# Altium schematic sheet KlusterLab HMI.SchDoc("KlusterLab HMI")
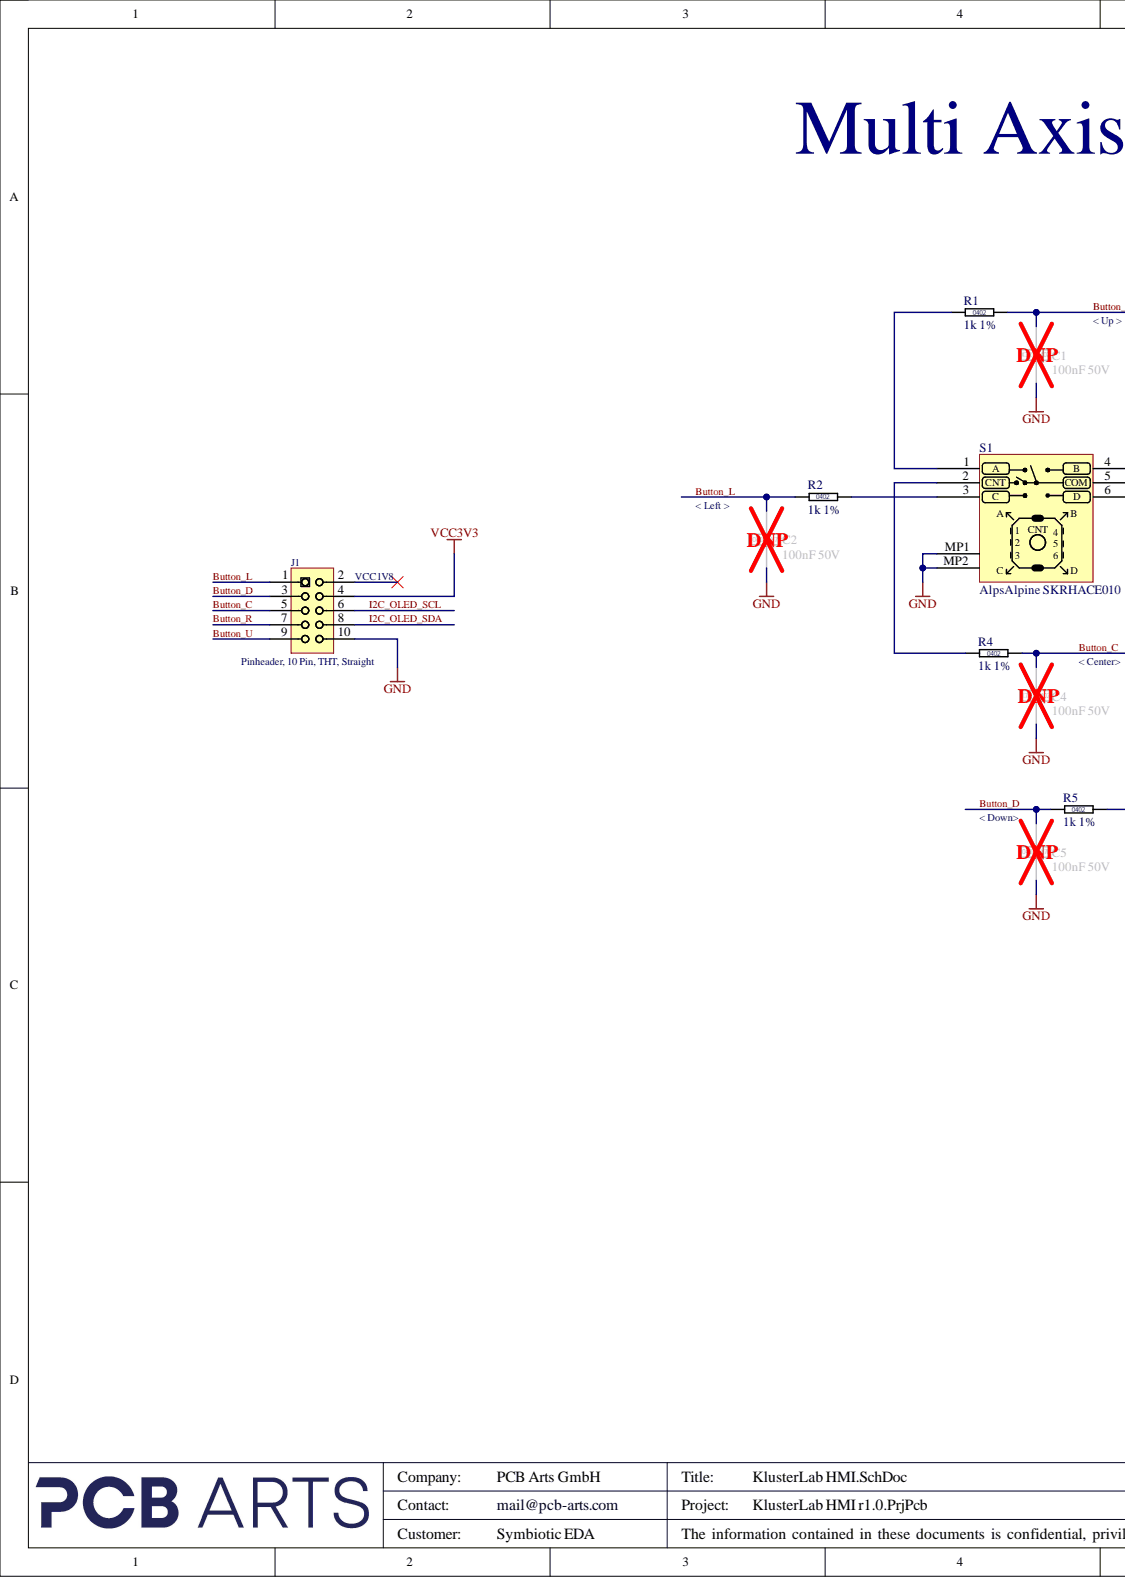
[diagram p0: KlusterLab HMI.SchDoc, left]
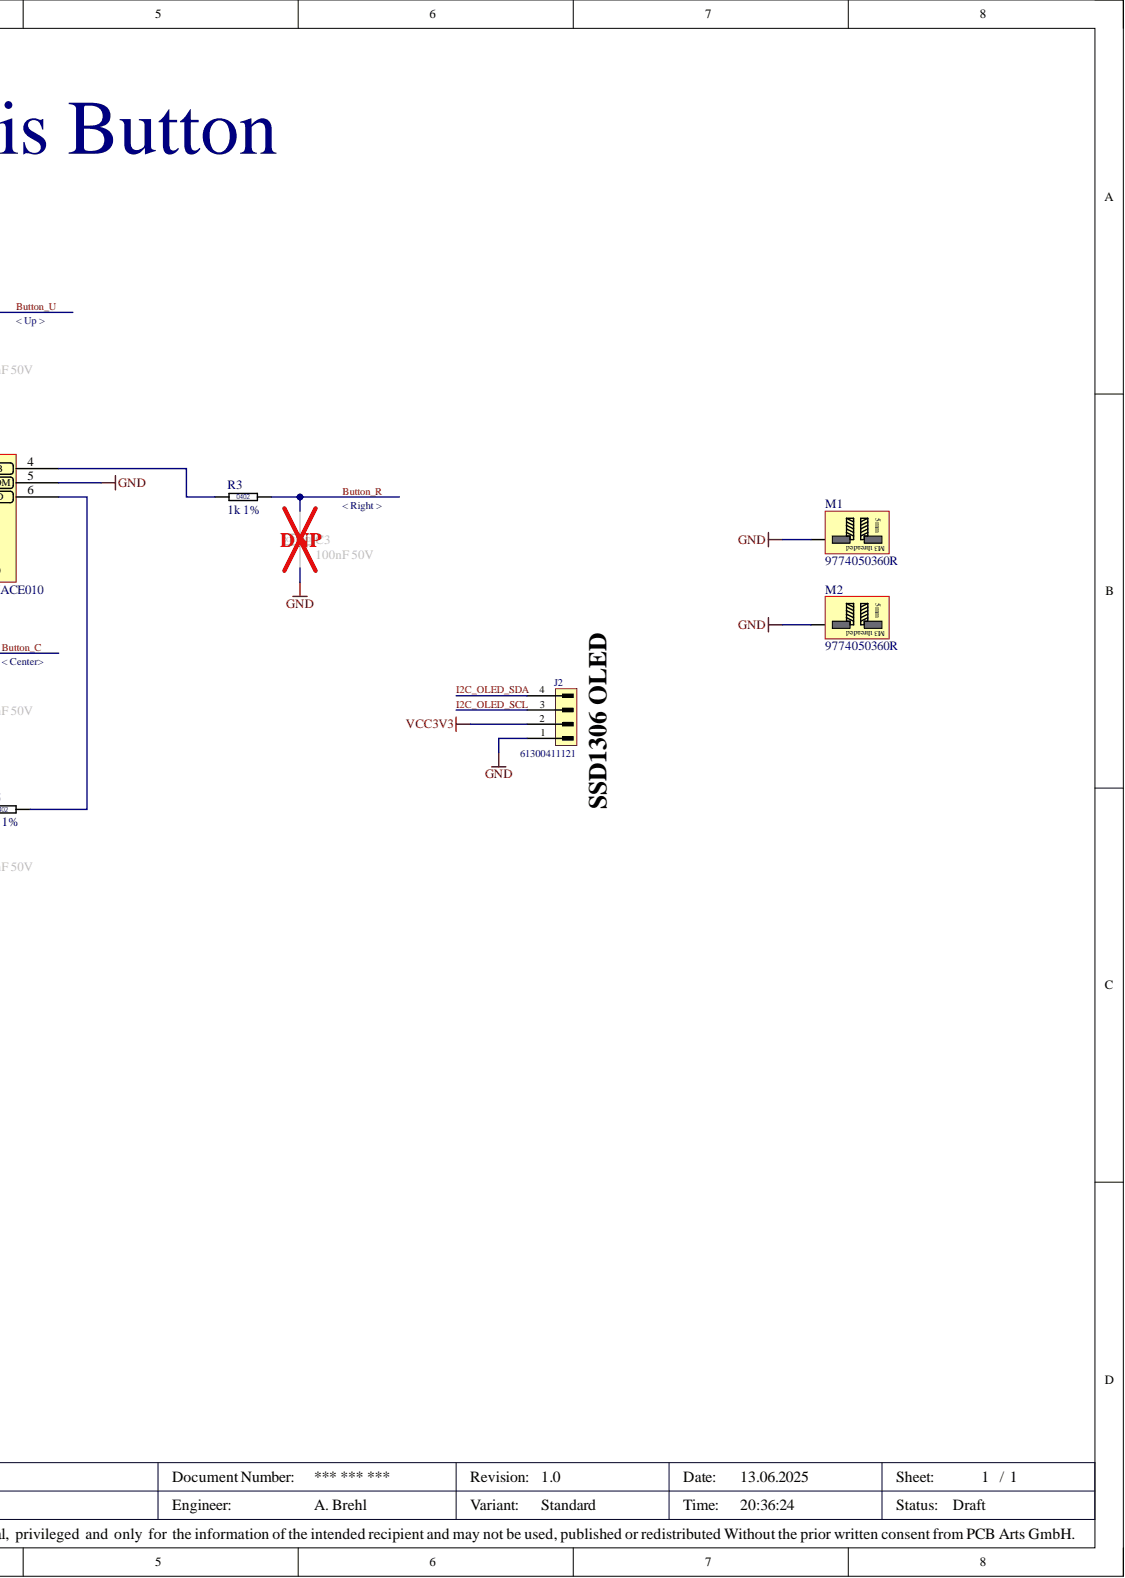
[diagram p1: KlusterLab HMI.SchDoc, right]

## Parts
C1  100nF 50V  [CAP_0402_L]  (no BOM)
C2  100nF 50V  [CAP_0402_L]  (no BOM)
C3  100nF 50V  [CAP_0402_L]  (no BOM)
C4  100nF 50V  [CAP_0402_L]  (no BOM)
C5  100nF 50V  [CAP_0402_L]  (no BOM)
J1  Pinheader, 10 Pin, THT, Straight  [62701021621]
J2  value 61300411121  Order Code #1: 61300411121  [WE_61300411121]  (hidden comment: WE / WR-PHD / 61300411121 / PinHeader / 1x4 Pin / RM2.54 / Top Entry / Male)
M1  9774050360R  [9774050360R]
M2  9774050360R  [9774050360R]
R1  1k 1%  [RES_0402_L]
R2  1k 1%  [RES_0402_L]
R3  1k 1%  [RES_0402_L]
R4  1k 1%  [RES_0402_L]
R5  1k 1%  [RES_0402_L]
S1  AlpsAlpine SKRHACE010  Manufacturer 1 Prt. Nr.: SKRHACE010  [AlpsAlpine_SKRHACE010]

## Nets
GND: C1.2 C2.2 C3.2 C4.2 C5.2 J1.10 J2.1 M1.1 M2.1 S1.5(COM) S1.MP1 S1.MP2(C)
VCC3V3: J1.4 J2.2
Button_C: C4.1 J1.5 R4.1
Button_D: C5.1 J1.3 R5.1
Button_L: C2.1 J1.1 R2.1
Button_R: C3.1 J1.7 R3.1
Button_U: C1.1 J1.9 R1.1
I2C_OLED_SCL: J1.6 J2.3
I2C_OLED_SDA: J1.8 J2.4
NetR1_2: R1.2 S1.1(A)
NetR2_2: R2.2 S1.3(C)
NetR3_2: R3.2 S1.4(B)
NetR4_2: R4.2 S1.2(CNT)
NetR5_2: R5.2 S1.6(D)

Not connected: J1 2

## Library data of the parts
C1-C5 = CAP_0402_100nF_50V: Multilayer Ceramic Capacitors MLCC - SMD/SMT 0402 50VDC; Manufacturer 1: Murata Electronics; Manufacturer 1 Prt. Nr.: GCM155L8EH104KE07D; Manufacturer 2 Prt. Nr.: UMK105B7104KV-FR; Library Name: Cap.SchLib; Manufacturer 2: TDK
J1 = 62701021621_Box Pin header THT 1.27mm 10Pol Straight: Box Pin header THT 1.27mm 10Pol Straight; Manufacturer 1: Würth Elektronik; Component Kind: Standard; Library Name: Conn.SchLib; Manufacturer 1 Prt. Nr.: 62701021621
J2 = CMP-CON-000024-2: WR-PHD Pin Header; Manufacturer #1: Wuerth Elektronik; Component Kind: Standard; Entry Direction: Top Entry; Gender: Male; Max Operating Temperature: 105°C; Min Operating Temperature: -40°C; Mount: Surface Mount; Pin Count: 4; Symbol Text: 61300411121; Library Name: PCB ARTS GMBH
M1 M2 = Würth Standoff M3 h:5mm: Würth Standoff M3 h:5mm 9774050360R; Manufacturer 1: Würth Elektronik; Component Kind: Standard; Library Name: Electro_Mech.SchLib; Manufacturer 1 Prt. Nr.: 9774050360R
R1-R5 = RES_0402_1k_1%: Thick Film Resistors - SMD 1kOhm 1%; Manufacturer 1: Vishay / Dale; Manufacturer 1 Prt. Nr.: CRCW04021K00FKEDC; Manufacturer 2 Prt. Nr.: RC0402FR-7W1KL; Component Kind: Standard; Library Name: Res.SchLib; Manufacturer 2: Yageo
S1 = AlpsAlpine_ SKRHACE010_Multi-Directional-Switches_4-directions_center-push: Multi-Directional Switches, 4-directions, center-push; Component Kind: Standard; Library Name: Electro_Mech.SchLib; Manufacturer 1: Alps Alpine
